# Altium schematic sheet Battery Charge Controller.SchDoc
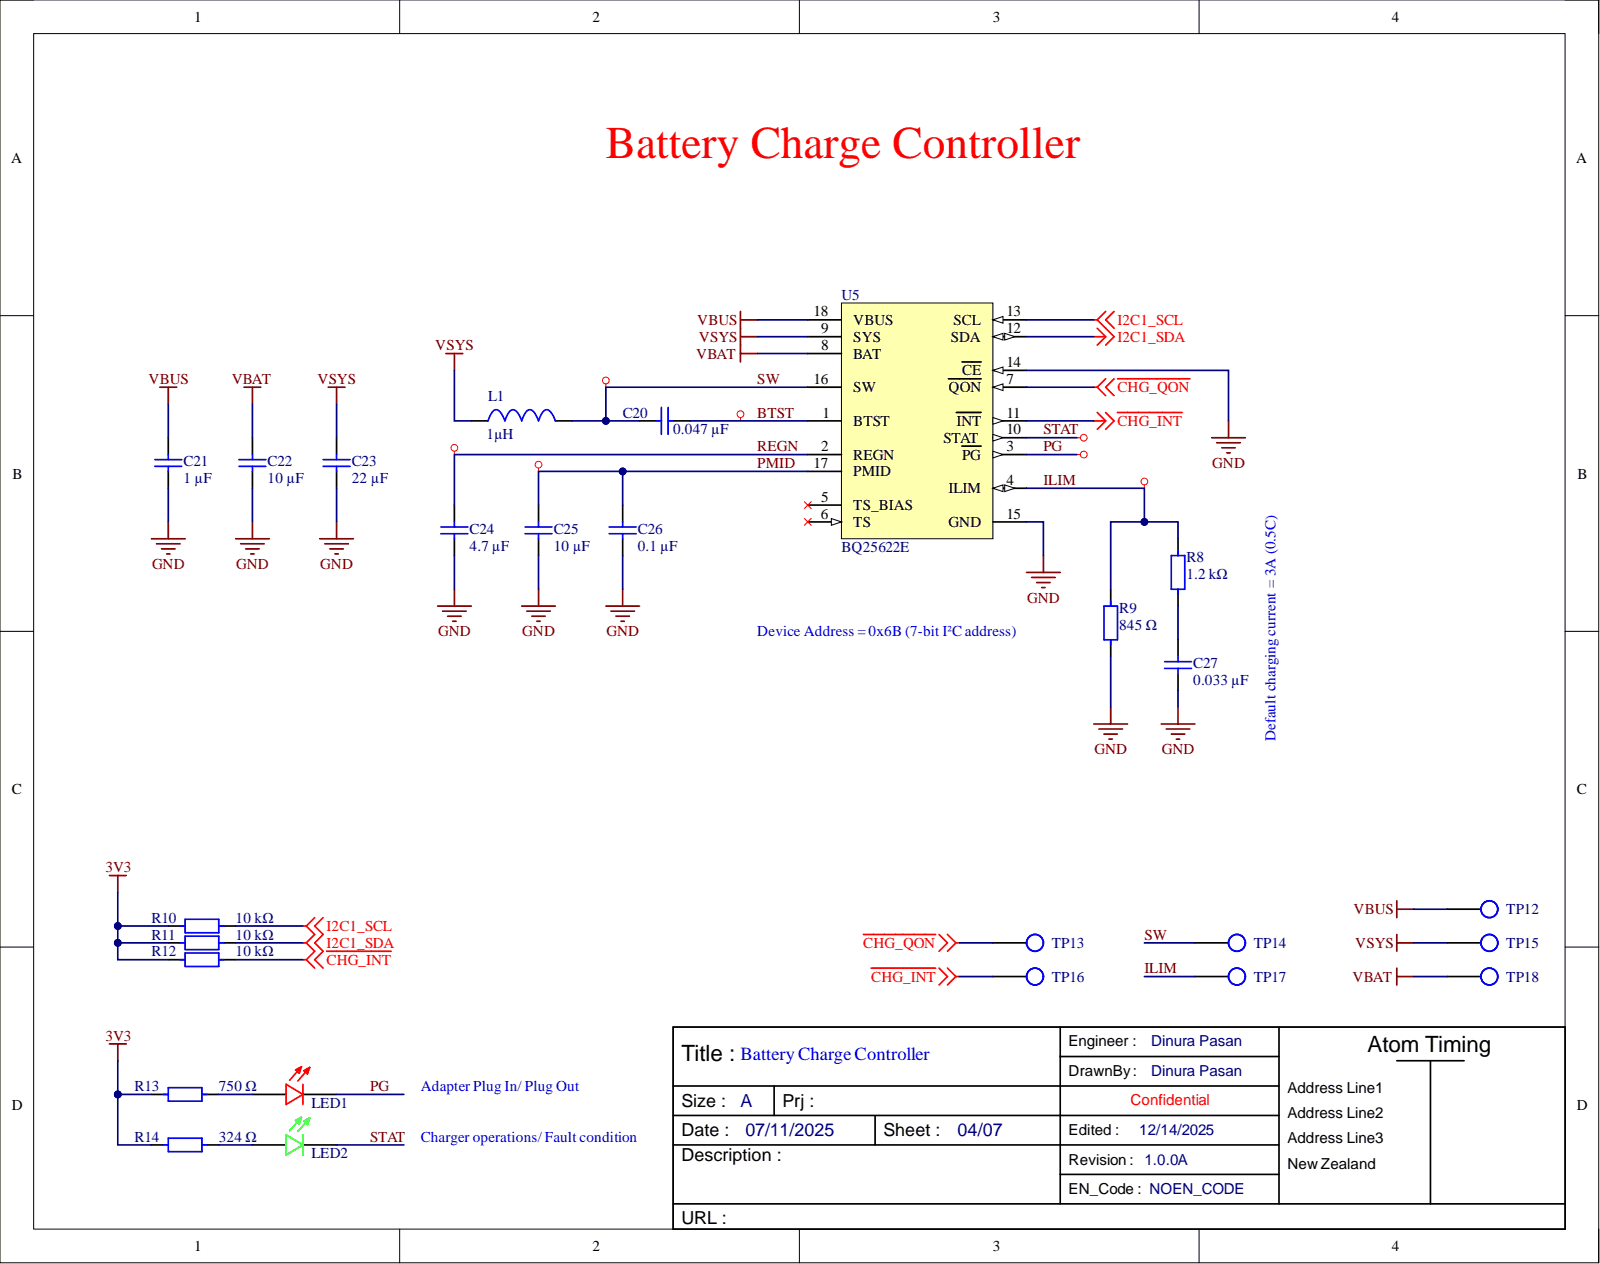
[diagram p0: Battery Charge Controller.SchDoc]

## Parts
C20  0.047 µF  [TDK_CGA0805N]
C21  1 µF  [TDK_CGA0805N]
C22  10 µF  [TDK_CGA1206N]
C23  22 µF  [TDK_CGA1206N]
C24  4.7 µF  [TDK_CGA0805N]
C25  10 µF  [TDK_CGA0805N]
C26  0.1 µF  [TDK_CGA0805N]
C27  0.033 µF  [TDK_CGA0805N]
L1  1µH  [BMRA000404201R0MA1]
LED1  APT2012LSECK/J3-PRV  [Kingbright_HELI2_0805]  (value not drawn)
LED2  APT2012LZGCK  [Kingbright_HELI2_0805]  (value not drawn)
R8  1.2 kΩ  [Panasonic_ERA2AN]
R9  845 Ω  [Panasonic_ERA2AN]
R10  10 kΩ  [Panasonic_ERA2AN]
R11  10 kΩ  [Panasonic_ERA2AN]
R12  10 kΩ  [Panasonic_ERA2AN]
R13  750 Ω  [YAGEO_RT0402N]
R14  324 Ω  [Panasonic_ERA2AN]
TP12  TestPoint  [TESTPOINT]  (value not drawn)
TP13  TestPoint  [TESTPOINT]  (value not drawn)
TP14  TestPoint  [TESTPOINT]  (value not drawn)
TP15  TestPoint  [TESTPOINT]  (value not drawn)
TP16  TestPoint  [TESTPOINT]  (value not drawn)
TP17  TestPoint  [TESTPOINT]  (value not drawn)
TP18  TestPoint  [TESTPOINT]  (value not drawn)
U5  BQ25622E  [TI-RYK0018A]

## Nets
3V3: R10.1 R11.1 R12.1 R13.1 R14.1
~{CHG_INT}: R12.2 TP16.1 U5.11(INT)
~{CHG_QON}: TP13.1 U5.7(QON)
GND: C21.1 C22.1 C23.1 C24.2 C25.1 C26.1 C27.1 R9.1 U5.14(CE) U5.15(GND)
I2C1_SCL: R10.2 U5.13(SCL)
I2C1_SDA: R11.2 U5.12(SDA)
VBAT: C22.2 TP18.1 U5.8(BAT)
VBUS: C21.2 TP12.1 U5.18(VBUS)
VSYS: C23.2 L1.1 TP15.1 U5.9(SYS)
BTST: C20.2 U5.1(BTST)
ILIM: R8.2 R9.2 TP17.1 U5.4(ILIM)
PG: LED1.C U5.3(PG)
PMID: C25.2 C26.2 U5.17(PMID)
REGN: C24.1 U5.2(REGN)
STAT: LED2.C U5.10(STAT)
SW: C20.1 L1.2 TP14.1 U5.16(SW)
NetC27_2: C27.2 R8.1
NetLED1_A: LED1.A R13.2
NetLED2_A: LED2.A R14.2

Not connected: U5 5(TS_BIAS) 6(TS)

## Library data of the parts
C20 = CGA4J2X7R2A473K125AA: 0.047 µF ±10% 100V X7R -55°C ~ 125°C 0805 (2012 Metric); Manufacturer: TDK Corporation; Database Table Name: Sheet1$; Eco Plan: RoHS & Green; Initial Voltage: 0V; TEMP: 25; Value: 0.047u; Capacitance: 0.047 µF; Category: Ceramic Capacitor (MLCC); Component Kind: Standard; Datasheet: https://product.tdk.com/system/files/dam/doc/product/capacitor/ceramic/mlcc/catalog/mlcc_automotive_general_en.pdf; Library Name: MLCC.DbLib; Manufacturer Part Number: CGA4J2X7R2A473K125AA; Operating Temperature: -55°C ~ 125°C; Package / Case: 0805 (2012 Metric); Ratings: AEC-Q200; Series: CGA; Simulation: C_Capacitor_Default; Size / Dimension: 0.079" L x 0.049" W (2.00mm x 1.25mm); Supplier 1: Digi-Key; Supplier Part Number 1: 445-5825-2-ND; Temperature Coefficient: X7R; Thickness (Max): 0.057" (1.45mm); Tolerance: ±10%; Voltage - Rated: 100V
C21 = CGA4J3X7R1H105K125AB: 1 µF ±10% 50V X7R -55°C ~ 125°C 0805 (2012 Metric); Manufacturer: TDK Corporation; Database Table Name: Sheet1$; Eco Plan: RoHS & Green; Initial Voltage: 0V; TEMP: 25; Value: 1u; Capacitance: 1 µF; Category: Ceramic Capacitor (MLCC); Component Kind: Standard; Datasheet: https://product.tdk.com/system/files/dam/doc/product/capacitor/ceramic/mlcc/catalog/mlcc_automotive_general_en.pdf; Library Name: MLCC.DbLib; Manufacturer Part Number: CGA4J3X7R1H105K125AB; Operating Temperature: -55°C ~ 125°C; Package / Case: 0805 (2012 Metric); Ratings: AEC-Q200; Series: CGA; Simulation: C_Capacitor_Default; Size / Dimension: 0.079" L x 0.049" W (2.00mm x 1.25mm); Supplier 1: Digi-Key; Supplier Part Number 1: 445-6961-2-ND; Temperature Coefficient: X7R; Thickness (Max): 0.059" (1.50mm); Tolerance: ±10%; Voltage - Rated: 50V
C22 = CGA5L1X7R1C106M160AC: 10 µF ±20% 16V X7R -55°C ~ 125°C 1206 (3216 Metric); Manufacturer: TDK Corporation; Database Table Name: Sheet1$; Eco Plan: RoHS & Green; Initial Voltage: 0V; TEMP: 25; Value: 10u; Capacitance: 10 µF; Category: Ceramic Capacitor (MLCC); Component Kind: Standard; Datasheet: https://product.tdk.com/system/files/dam/doc/product/capacitor/ceramic/mlcc/catalog/mlcc_automotive_general_en.pdf; Library Name: MLCC.DbLib; Manufacturer Part Number: CGA5L1X7R1C106M160AC; Operating Temperature: -55°C ~ 125°C; Package / Case: 1206 (3216 Metric); Ratings: AEC-Q200; Series: CGA; Simulation: C_Capacitor_Default; Size / Dimension: 0.126" L x 0.063" W (3.20mm x 1.60mm); Supplier 1: Digi-Key; Supplier Part Number 1: 445-12903-2-ND; Temperature Coefficient: X7R; Thickness (Max): 0.075" (1.90mm); Tolerance: ±20%; Voltage - Rated: 16V
C23 = CGA5L1X5R1C226M160AC: 22 µF ±20% 16V X5R -55°C ~ 85°C 1206 (3216 Metric); Manufacturer: TDK Corporation; Database Table Name: Sheet1$; Eco Plan: RoHS & Green; Initial Voltage: 0V; TEMP: 25; Value: 22u; Capacitance: 22 µF; Category: Ceramic Capacitor (MLCC); Component Kind: Standard; Datasheet: https://product.tdk.com/system/files/dam/doc/product/capacitor/ceramic/mlcc/catalog/mlcc_automotive_general_en.pdf; Library Name: MLCC.DbLib; Manufacturer Part Number: CGA5L1X5R1C226M160AC; Operating Temperature: -55°C ~ 85°C; Package / Case: 1206 (3216 Metric); Ratings: AEC-Q200; Series: CGA; Simulation: C_Capacitor_Default; Size / Dimension: 0.126" L x 0.063" W (3.20mm x 1.60mm); Supplier 1: Digi-Key; Supplier Part Number 1: 445-12847-2-ND; Temperature Coefficient: X5R; Thickness (Max): 0.075" (1.90mm); Tolerance: ±20%; Voltage - Rated: 16V
C24 = CGA4J1X7R1H475K125AC: 4.7 µF ±10% 50V X7R -55°C ~ 125°C 0805 (2012 Metric); Manufacturer: TDK Corporation; Database Table Name: Sheet1$; Eco Plan: RoHS & Green; Initial Voltage: 0V; TEMP: 25; Value: 4.7u; Capacitance: 4.7 µF; Category: Ceramic Capacitor (MLCC); Component Kind: Standard; Datasheet: https://product.tdk.com/system/files/dam/doc/product/capacitor/ceramic/mlcc/catalog/mlcc_automotive_general_en.pdf; Library Name: MLCC.DbLib; Manufacturer Part Number: CGA4J1X7R1H475K125AC; Operating Temperature: -55°C ~ 125°C; Package / Case: 0805 (2012 Metric); Ratings: AEC-Q200; Series: CGA; Simulation: C_Capacitor_Default; Size / Dimension: 0.079" L x 0.049" W (2.00mm x 1.25mm); Supplier 1: Digi-Key; Supplier Part Number 1: 445-181404-2-ND; Temperature Coefficient: X7R; Thickness (Max): 0.059" (1.50mm); Tolerance: ±10%; Voltage - Rated: 50V
C25 = CGA4J1X7S1E106K125AC: 10 µF ±10% 25V X7S -55°C ~ 125°C 0805 (2012 Metric); Manufacturer: TDK Corporation; Database Table Name: Sheet1$; Eco Plan: RoHS & Green; Initial Voltage: 0V; TEMP: 25; Value: 10u; Capacitance: 10 µF; Category: Ceramic Capacitor (MLCC); Component Kind: Standard; Datasheet: https://product.tdk.com/system/files/dam/doc/product/capacitor/ceramic/mlcc/catalog/mlcc_automotive_general_en.pdf; Library Name: MLCC.DbLib; Manufacturer Part Number: CGA4J1X7S1E106K125AC; Operating Temperature: -55°C ~ 125°C; Package / Case: 0805 (2012 Metric); Ratings: AEC-Q200; Series: CGA; Simulation: C_Capacitor_Default; Size / Dimension: 0.079" L x 0.049" W (2.00mm x 1.25mm); Supplier 1: Digi-Key; Supplier Part Number 1: 445-181405-2-ND; Temperature Coefficient: X7S; Thickness (Max): 0.059" (1.50mm); Tolerance: ±10%; Voltage - Rated: 25V
C26 = CGA4J2X7R2A104K125AE: 0.1 µF ±10% 100V X7R -55°C ~ 125°C 0805 (2012 Metric); Manufacturer: TDK Corporation; Database Table Name: Sheet1$; Eco Plan: RoHS & Green; Initial Voltage: 0V; TEMP: 25; Value: 0.1u; Capacitance: 0.1 µF; Category: Ceramic Capacitor (MLCC); Component Kind: Standard; Datasheet: https://product.tdk.com/system/files/dam/doc/product/capacitor/ceramic/mlcc/catalog/mlcc_automotive_general_en.pdf; Library Name: MLCC.DbLib; Manufacturer Part Number: CGA4J2X7R2A104K125AE; Operating Temperature: -55°C ~ 125°C; Package / Case: 0805 (2012 Metric); Ratings: AEC-Q200; Series: CGA; Simulation: C_Capacitor_Default; Size / Dimension: 0.079" L x 0.049" W (2.00mm x 1.25mm); Supplier 1: Digi-Key; Supplier Part Number 1: 445-15961-2-ND; Temperature Coefficient: X7R; Thickness (Max): 0.059" (1.50mm); Tolerance: ±10%; Voltage - Rated: 100V
C27 = CGA4J1C0G2A333J125AE: 0.033 µF ±5% 100V C0G, NP0 -55°C ~ 125°C 0805 (2012 Metric); Manufacturer: TDK Corporation; Database Table Name: Sheet1$; Eco Plan: RoHS & Green; Initial Voltage: 0V; TEMP: 25; Value: 0.033u; Capacitance: 0.033 µF; Category: Ceramic Capacitor (MLCC); Component Kind: Standard; Datasheet: https://product.tdk.com/system/files/dam/doc/product/capacitor/ceramic/mlcc/catalog/mlcc_automotive_general_en.pdf; Library Name: MLCC.DbLib; Manufacturer Part Number: CGA4J1C0G2A333J125AE; Operating Temperature: -55°C ~ 125°C; Package / Case: 0805 (2012 Metric); Ratings: AEC-Q200; Series: CGA; Simulation: C_Capacitor_Default; Size / Dimension: 0.079" L x 0.049" W (2.00mm x 1.25mm); Supplier 1: Digi-Key; Supplier Part Number 1: 445-175692-2-ND; Temperature Coefficient: C0G, NP0; Thickness (Max): 0.059" (1.50mm); Tolerance: ±5%; Voltage - Rated: 100V
L1 = BMRA000404201R0MA1: 1 µH Shielded Molded Inductor 4.5 A 27mOhm Max Nonstandard; Manufacturer 1: Pulse Electronics; Case/Package 1: 1816; Category 1: Fixed Inductors; Core Material: Composite; DC Resistance (DCR): 27mΩ; Description 1: Inductor Power Molded/Shielded Wirewound 1uH 20% 100kHz 4.5A SMD T/R; Max Current Rating: 4.5A; Number of Circuits: 1; Shielding: Yes; Test Frequency: 100kHz; Tolerance: 20%; Component Kind: Standard; ComponentLink1Description: https://datasheet.octopart.com:443/BMRA000404201R0MA1-Pulse-datasheet-180094538.pdf?src-supplier=IHS; ComponentLink1URL: https://datasheet.octopart.com:443/BMRA000404201R0MA1-Pulse-datasheet-180094538.pdf?src-supplier=IHS; ComponentLink2Description: https://datasheet.octopart.com:443/BMRA000404201R0MA1-Pulse-datasheet-155427411.pdf?src-supplier=IHS; ComponentLink2URL: https://datasheet.octopart.com:443/BMRA000404201R0MA1-Pulse-datasheet-155427411.pdf?src-supplier=IHS; Height: 2mm; Inductance: 1µH; Library Name: Components.IntLib; Lifecycle Status: Production; Manufacturer Part Number 1: BMRA000404201R0MA1; Max Operating Temperature 1: 125°C; Min Operating Temperature 1: -55°C; Number of Terminals: 2; REACH SVHC: Yes; RoHS 1: Compliant; Supplier 1: DigiKey; Supplier Part Number 1: 553-BMRA000404201R0MA1CT-ND; Width: 4.1mm
LED1 = APT2012LSECK/J3-PRV: Red 625nm LED Indication - Discrete 1.8V 0805 (2012 Metric); Manufacturer: Kingbright; Database Table Name: Sheet1$; Eco Plan: RoHS & Green; IF @ VF: 2mA; Lens Color: Colorless; Lens Size: 1.20mm x 1.25mm; Lens Style: Rectangle with Flat Top; Lens Transparency: Clear; Luminous Intensity: 40mcd; Power Dissipation: 84mW; Category: Chip LED; Color: Red; Component Kind: Standard; Datasheet: https://www.KingbrightUSA.com/images/catalog/SPEC/APT2012LSECK-J3-PRV.pdf; Dominant wave-length: 625nm; Forward Voltage: 1.8V; Height (Max): 0.75mm; Library Name: LED.DbLib; Manufacturer Part Number: APT2012LSECK/J3-PRV; Operating Temperature: -40°C to 85°C; Package / Case: 0805 (2012 Metric); Ratings: -; Series: HELI2; Size / Dimension: 2.00mm L x 1.25mm W; Storage Temperature: -40°C to 85°C; Supplier 1: Digi-Key; Supplier Part Number 1: 754-1935-2-ND
LED2 = APT2012LZGCK: Green 525nm LED Indication - Discrete 2.65V 0805 (2012 Metric); Manufacturer: Kingbright; Database Table Name: Sheet1$; Eco Plan: RoHS & Green; IF @ VF: 2mA; Lens Color: Colorless; Lens Size: 1.20mm x 1.25mm; Lens Style: Rectangle with Flat Top; Lens Transparency: Clear; Luminous Intensity: 50mcd; Power Dissipation: 102.5mW; Category: Chip LED; Color: Green; Component Kind: Standard; Datasheet: https://www.KingbrightUSA.com/images/catalog/SPEC/APT2012LZGCK.pdf; Dominant wave-length: 525nm; Forward Voltage: 2.65V; Height (Max): 0.75mm; Library Name: LED.DbLib; Manufacturer Part Number: APT2012LZGCK; Operating Temperature: -40°C to 85°C; Package / Case: 0805 (2012 Metric); Ratings: -; Series: HELI2; Size / Dimension: 2.00mm L x 1.25mm W; Storage Temperature: -40°C to 85°C; Supplier 1: Digi-Key; Supplier Part Number 1: 754-1939-2-ND
R8 = ERA-2ARB122X: 1.2 kΩ ±0.1% 0.063W, 1/16W Thin Film 0402 (1005 Metric) 50V ±10ppm/°C -55°C ~ 155°C; Manufacturer: Panasonic Electronic Components; Database Table Name: Sheet1$; Eco Plan: RoHS & Green; Maximum Overload Voltage: 100V; Rated Voltage: √(PxR); TC: 10; TC1: 0; TEMP: 25; Value: 1.2kΩ; Category: SMD Thin Film Resistor; Component Kind: Standard; Composition: Thin Film; Datasheet: https://industrial.panasonic.com/cdbs/www-data/pdf/RDM0000/AOA0000C307.pdf; Height - Seated (Max): 0.016" (0.40mm); Library Name: SMD_Thin_Film_Resistors.DbLib; Manufacturer Part Number: ERA-2ARB122X; Maximum Working Voltage: 50V; Operating Temperature: -55°C ~ 155°C; Package / Case: 0402 (1005 Metric); Power (Watts): 0.063W, 1/16W; Ratings: AEC-Q200; Resistance: 1.2 kΩ; Series: ERA; Simulation: R_Resistor_Default; Size / Dimension: 0.039" L x 0.020" W (1.00mm x 0.50mm); Supplier 1: Digi-Key; Supplier Part Number 1: P1.2KCPTR-ND; TC2: 0; Temperature Coefficient: ±10ppm/°C; Tolerance: ±0.1%
R9 = ERA-2AEB8450X: 845 Ω ±0.1% 0.063W, 1/16W Thin Film 0402 (1005 Metric) 50V ±25ppm/°C -55°C ~ 155°C; Manufacturer: Panasonic Electronic Components; Database Table Name: Sheet1$; Eco Plan: RoHS & Green; Maximum Overload Voltage: 100V; Rated Voltage: √(PxR); TC: 25; TC1: 0; Value: 845Ω; Category: SMD Thin Film Resistor; Component Kind: Standard; Composition: Thin Film; Datasheet: https://industrial.panasonic.com/cdbs/www-data/pdf/RDM0000/AOA0000C307.pdf; Height - Seated (Max): 0.016" (0.40mm); Library Name: SMD_Thin_Film_Resistors.DbLib; Manufacturer Part Number: ERA-2AEB8450X; Maximum Working Voltage: 50V; Operating Temperature: -55°C ~ 155°C; Package / Case: 0402 (1005 Metric); Power (Watts): 0.063W, 1/16W; Ratings: AEC-Q200; Resistance: 845 Ω; Series: ERA; Simulation: R_Resistor_Default; Size / Dimension: 0.039" L x 0.020" W (1.00mm x 0.50mm); Supplier 1: Digi-Key; Supplier Part Number 1: P845DCTR-ND; TC2: 0; TEMP: 25; Temperature Coefficient: ±25ppm/°C; Tolerance: ±0.1%
R10-R12 = ERA-2ARB103X: 10 kΩ ±0.1% 0.063W, 1/16W Thin Film 0402 (1005 Metric) 50V ±10ppm/°C -55°C ~ 155°C; Manufacturer: Panasonic Electronic Components; Database Table Name: Sheet1$; Eco Plan: RoHS & Green; Maximum Overload Voltage: 100V; Rated Voltage: √(PxR); TC: 10; TC1: 0; TEMP: 25; Value: 10kΩ; Category: SMD Thin Film Resistor; Component Kind: Standard; Composition: Thin Film; Datasheet: https://industrial.panasonic.com/cdbs/www-data/pdf/RDM0000/AOA0000C307.pdf; Height - Seated (Max): 0.016" (0.40mm); Library Name: SMD_Thin_Film_Resistors.DbLib; Manufacturer Part Number: ERA-2ARB103X; Maximum Working Voltage: 50V; Operating Temperature: -55°C ~ 155°C; Package / Case: 0402 (1005 Metric); Power (Watts): 0.063W, 1/16W; Ratings: AEC-Q200; Resistance: 10 kΩ; Series: ERA; Simulation: R_Resistor_Default; Size / Dimension: 0.039" L x 0.020" W (1.00mm x 0.50mm); Supplier 1: Digi-Key; Supplier Part Number 1: P10KCPTR-ND; TC2: 0; Temperature Coefficient: ±10ppm/°C; Tolerance: ±0.1%
R13 = RT0402BRD07750RL: 750 Ω ±0.1% 0.063W, 1/16W Thin Film 0402 (1005 Metric) 50V ±25ppm/°C -55°C ~ 155°C; Manufacturer: YAGEO; Database Table Name: Sheet1$; Eco Plan: RoHS & Green; Maximum Overload Voltage: 100V; Rated Voltage: √(PxR); TC: 25; TC1: 0; Value: 750Ω; Category: SMD Thin Film Resistor; Component Kind: Standard; Composition: Thin Film; Datasheet: https://www.yageo.com/upload/media/product/products/datasheet/rchip/PYu-RT_1-to-0.01_RoHS_L_14.pdf; Height - Seated (Max): 0.014" (0.35mm); Library Name: SMD_Thin_Film_Resistors.DbLib; Manufacturer Part Number: RT0402BRD07750RL; Maximum Working Voltage: 50V; Operating Temperature: -55°C ~ 155°C; Package / Case: 0402 (1005 Metric); Power (Watts): 0.063W, 1/16W; Ratings: -; Resistance: 750 Ω; Series: RT; Simulation: R_Resistor_Default; Size / Dimension: 0.039" L x 0.020" W (1.00mm x 0.50mm); Supplier 1: Digi-Key; Supplier Part Number 1: YAG1477TR-ND; TC2: 0; TEMP: 25; Temperature Coefficient: ±25ppm/°C; Tolerance: ±0.1%
R14 = ERA-2AEB3240X: 324 Ω ±0.1% 0.063W, 1/16W Thin Film 0402 (1005 Metric) 50V ±25ppm/°C -55°C ~ 155°C; Manufacturer: Panasonic Electronic Components; Database Table Name: Sheet1$; Eco Plan: RoHS & Green; Maximum Overload Voltage: 100V; Rated Voltage: √(PxR); TC: 25; TC1: 0; Value: 324Ω; Category: SMD Thin Film Resistor; Component Kind: Standard; Composition: Thin Film; Datasheet: https://industrial.panasonic.com/cdbs/www-data/pdf/RDM0000/AOA0000C307.pdf; Height - Seated (Max): 0.016" (0.40mm); Library Name: SMD_Thin_Film_Resistors.DbLib; Manufacturer Part Number: ERA-2AEB3240X; Maximum Working Voltage: 50V; Operating Temperature: -55°C ~ 155°C; Package / Case: 0402 (1005 Metric); Power (Watts): 0.063W, 1/16W; Ratings: AEC-Q200; Resistance: 324 Ω; Series: ERA; Simulation: R_Resistor_Default; Size / Dimension: 0.039" L x 0.020" W (1.00mm x 0.50mm); Supplier 1: Digi-Key; Supplier Part Number 1: P324DCTR-ND; TC2: 0; TEMP: 25; Temperature Coefficient: ±25ppm/°C; Tolerance: ±0.1%
TP12-TP18 = TestPoint: 1mm Test Point; Component Kind: Standard; Library Name: Common_library.IntLib
U5 = BQ25622E: I2C controlled one-cell 3-A buck battery charger with up to 18-V input, current limit, and ADC; Manufacturer 1: Texas Instruments; Manufacturer Lifecycle Status: ACTIVE; Manufacturer Part Number 1: BQ25622ERYKR; Category 1: Battery Management; Description 1: I²C controlled one-cell 3-A buck battery charger with up to 18-V input, current limit, and ADC 18-WQFN-HR -40 to 85; Number of Pins 1: 18; Component Kind: Standard; ComponentLink1Description: https://octopart.com:443/opatz8j6/c1?t=EFhaV0WMcojBEZl8jVlVSjcpTQ_9I1SIc4vR8UnI8sHyLBDmyMTCADh83FCiOebgVNQaVsQhE1R4PwCigc1P6fcVrMm0i7ydM_A4X-mtQfRiSHBlo4HupEHnsfu4zE8KbKWIZ97FNsH8bRKRdwujN3gXbG233Q2yrlJE23Z46C_84_lp6bbKgLf2ew-r0VxAaJjkpxgl0ppfCR6MMpHidcd9rF24E3HfhhAM6Pvg20wg-xwRkxcizNPG0fBJwjlb5iZ8HUMFvqFCW3QI0RpUwHy6iVM4N4aFLNHr6qbMM3VyDzl1dFLKmtLlrp5gUqkI7Z9F6HZRD2S7H_upWbX88mfWIIF9HcttMQlNCuEeTdM9nLalKtratdUcAIyTut3ONxo4hE6ULg&src-supplier=Texas+Instruments; ComponentLink1URL: https://octopart.com:443/opatz8j6/c1?t=EFhaV0WMcojBEZl8jVlVSjcpTQ_9I1SIc4vR8UnI8sHyLBDmyMTCADh83FCiOebgVNQaVsQhE1R4PwCigc1P6fcVrMm0i7ydM_A4X-mtQfRiSHBlo4HupEHnsfu4zE8KbKWIZ97FNsH8bRKRdwujN3gXbG233Q2yrlJE23Z46C_84_lp6bbKgLf2ew-r0VxAaJjkpxgl0ppfCR6MMpHidcd9rF24E3HfhhAM6Pvg20wg-xwRkxcizNPG0fBJwjlb5iZ8HUMFvqFCW3QI0RpUwHy6iVM4N4aFLNHr6qbMM3VyDzl1dFLKmtLlrp5gUqkI7Z9F6HZRD2S7H_upWbX88mfWIIF9HcttMQlNCuEeTdM9nLalKtratdUcAIyTut3ONxo4hE6ULg&src-supplier=Texas+Instruments; ComponentLink2Description: http://sigma.octopart.com:80/reach_statement/Texas-Instruments-company-64.pdf?src-supplier=Compliance+Statements; ComponentLink2URL: http://sigma.octopart.com:80/reach_statement/Texas-Instruments-company-64.pdf?src-supplier=Compliance+Statements; ComponentLink3Description: http://sigma.octopart.com:80/conflict_mineral_statement/Texas-Instruments-company-63.pdf?src-supplier=Compliance+Statements; ComponentLink3URL: http://sigma.octopart.com:80/conflict_mineral_statement/Texas-Instruments-company-63.pdf?src-supplier=Compliance+Statements; Height: 0m; Length: 2.5mm; Library Name: ICs.IntLib; Lifecycle Status: Production; RoHS 1: Compliant; Supplier 1: DigiKey; Supplier 2: DigiKey; Supplier 3: DigiKey; Supplier 4: Mouser; Supplier 5: Arrow Electronics; Supplier 6: LCSC; Supplier Part Number 1: 296-BQ25622ERYKRCT-ND; Supplier Part Number 2: 296-BQ25622ERYKRDKR-ND; Supplier Part Number 3: 296-BQ25622ERYKRTR-ND; Supplier Part Number 4: 595-BQ25622ERYKR; Supplier Part Number 5: BQ25622ERYKR; Supplier Part Number 6: C17702457; Thickness: 750µm; Width: 3mm
